# Altium schematic sheet SmartToy Main Board REV03 Schematic.SchDoc("SmartToy Main Board REV03 Schematic") (continued)
## Library data of the parts (continued)
U5 = MAX98357AETE+T: Amplifier IC 1-Channel (Mono) Class D 16-TQFN (3x3); Manufacturer: Maxim; Max Output Current: 1.6A; Max Output Power: 3.2W; Max Power Dissipation: 1.666W; Max Supply Voltage: 5.5V; Min Supply Voltage: 2.5V; Power Supply Rejection Ratio (PSRR): 77dB; Voltage Gain: 15dB; Component Kind: Standard; ComponentLink1Description: https://datasheet.ciiva.com/29970/max98357a-max98357b-29970280.pdf?src-supplier=Avnet; ComponentLink1URL: https://datasheet.ciiva.com/29970/max98357a-max98357b-29970280.pdf?src-supplier=Avnet; Contact Plating: Tin,Matte; Manufacturer Part Number: MAX98357AETE+T; Max Operating Temperature: 85°C; Min Operating Temperature: -40°C; Mount: Surface Mount; Number of Pins: 16; Packaging: Tape and Reel; Pricing 1: 1 = 2.44000, 10 = 2.19000, 25 = 2.06640, 100 = 1.65300, 250 = 1.44636, 500 = 1.40506, 1000 = 1.13100 (USD); Radiation Hardening: No; RoHS: Yes; Stock 1: 15213; Supplier 1: Digi-Key; Supplier Part Number 1: MAX98357AETE+TCT-ND; Library Name: Galvanic.SchLib
